# Altium schematic sheet Main Schematic.SchDoc("Main Schematic")
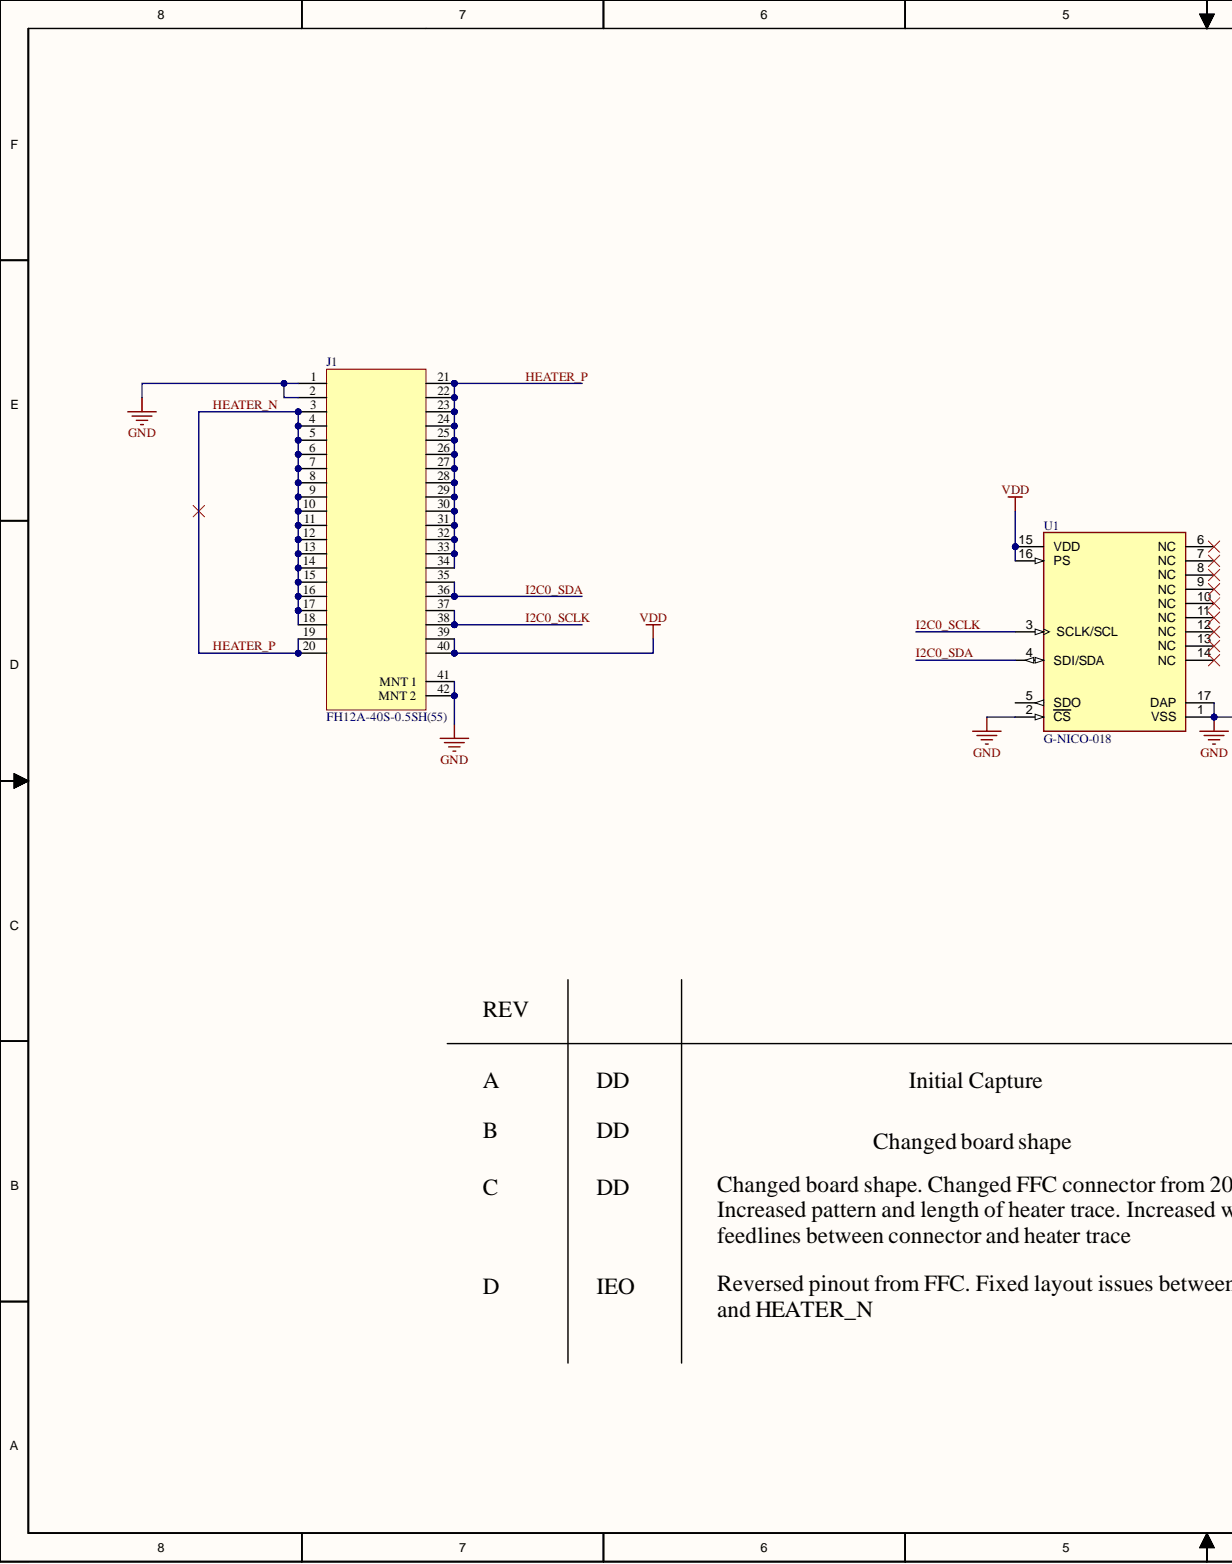
[diagram p0: Main Schematic.SchDoc, left]
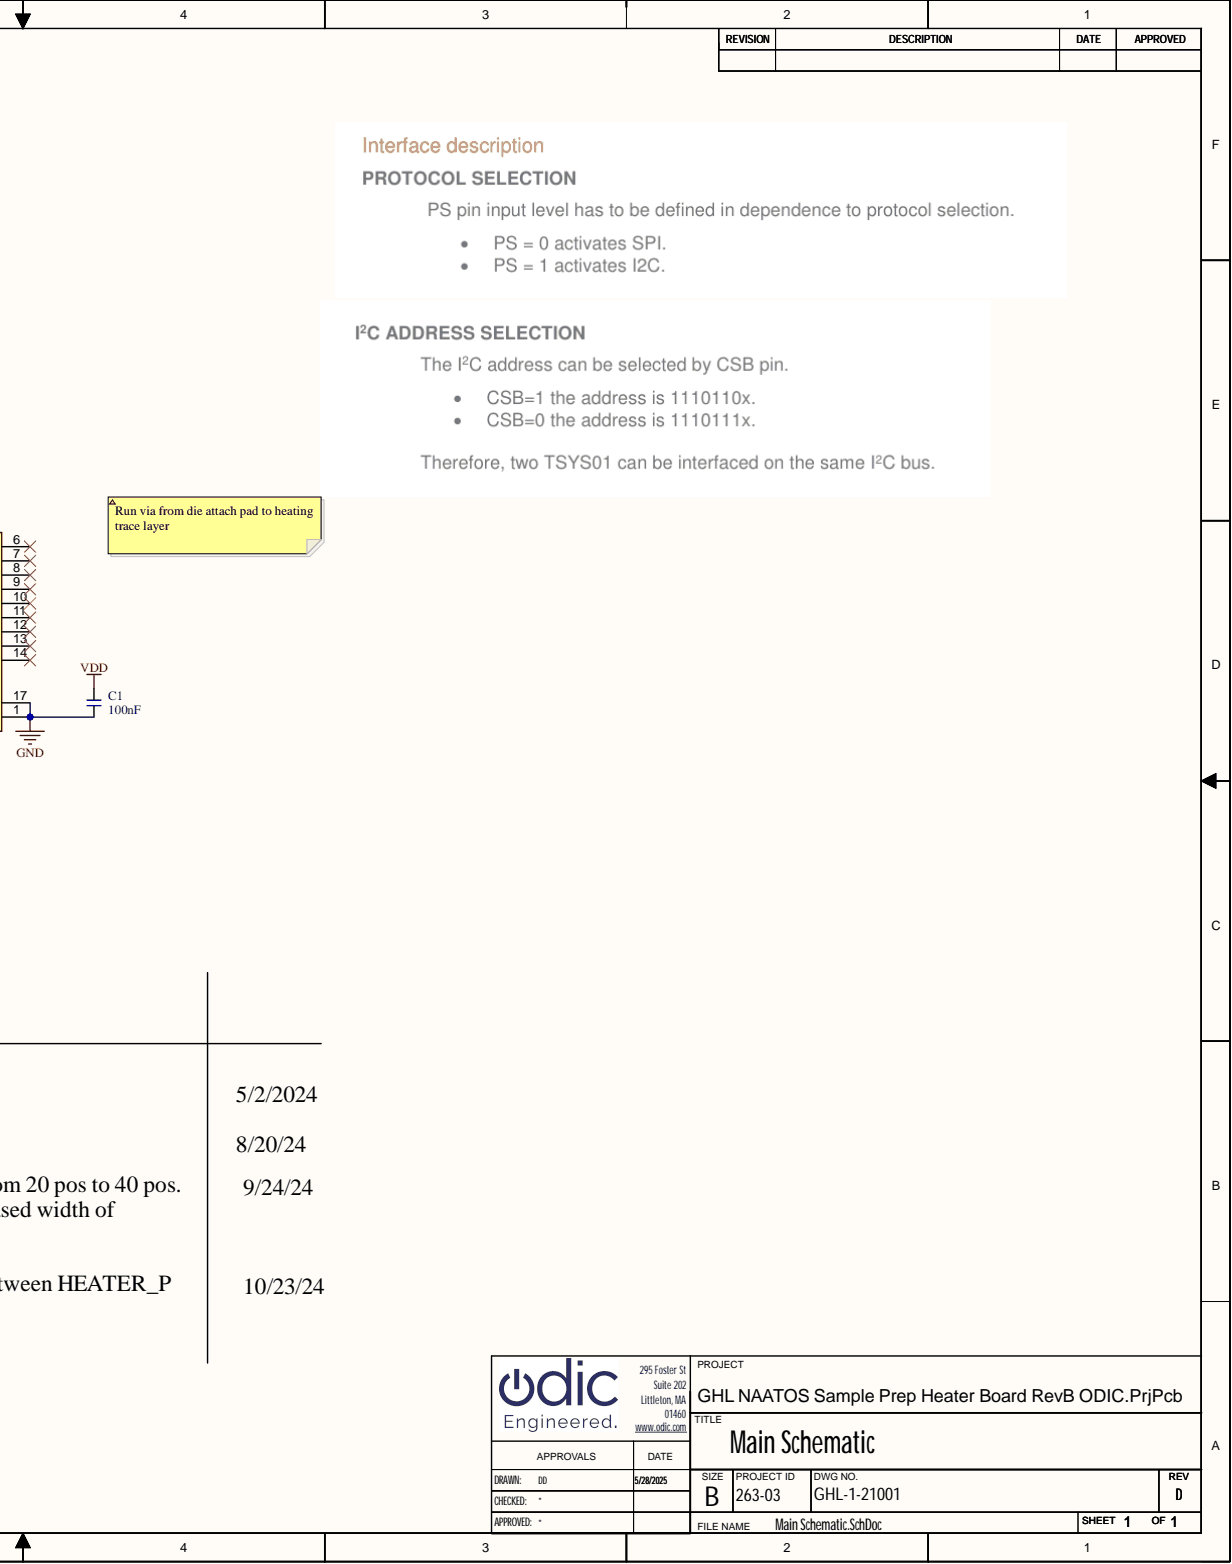
[diagram p1: Main Schematic.SchDoc, right]

## Parts
C1  100nF  [C0402]
J1  FH12A-40S-0.5SH(55)  [hirose_fh12a-40s-0p5sh55]
U1  G-NICO-018  [QFN16_4X4_P65mm]

## Nets
GND: C1.1 J1.1 J1.2 J1.41(MNT_1) J1.42(MNT_2) U1.1(VSS) U1.2(CS) U1.17(DAP)
VDD: C1.2 J1.39 J1.40 U1.15(VDD) U1.16(PS)
HEATER_N: J1.3 J1.4 J1.5 J1.6 J1.7 J1.8 J1.9 J1.10 J1.11 J1.12 J1.13 J1.14 J1.15 J1.16 J1.17 J1.18 J1.19 J1.20 J1.21 J1.22 J1.23 J1.24 J1.25 J1.26 J1.27 J1.28 J1.29 J1.30 J1.31 J1.32 J1.33 J1.34
I2C0_SCLK: J1.37 J1.38 U1.3(SCLK/SCL)
I2C0_SDA: J1.35 J1.36 U1.4(SDI/SDA)

Not connected: U1 5(SDO) 6(NC) 7(NC) 8(NC) 9(NC) 10(NC) 11(NC) 12(NC) 13(NC) 14(NC)

## Library data of the parts
C1 = CMP-00446-1: Ceramic capacitor SMD 0402 100nF 16V X7R 10%; Install: POP; Case Code (Imperial): 0402; Case Code (Metric): 1005; Case/Package: 0402; Component Kind: Standard; Construction: Flat; Contact Plating: Tin; Depth: 0.5mm; Dielectric: X7R; Height: 0.5mm; Lead Free: Lead Free; Length: 1mm; Material: Ceramic; Max Operating Temperature: 125°C; Min Operating Temperature: -55°C; Mounting Technology: Surface Mount; Package Quantity: 1; Packaging: Tape and Reel; Radiation Hardening: No; RoHS Compliant: Yes; Series: CL; Thickness: 0.022inch; Tolerance: 10%; Value: 100nF; Voltage: 16V; Voltage Rating: 16V; Voltage Rating (DC): 16V; Width: 0.02inch; Library Name: Odic Inc
J1 = CMP-002-00023-3: Conn Ffc/fpc Connector Skt 40 Pos 0.5MM Solder Ra SMD T/r / Conn Ffc Top 40POS 0.50MM R/a; Actuator Color: Brown; Actuator Material: Polyphenylene; Connector Type: Receptacle; Contact Pitch: 500um; Contact Resistance: 50mR; Contact Voltage Rating (AC): 50V; Current Rating: 500mA; Gender: Female; Insulation Resistance: 500MR; Mating Cycles: 20; Max Current Rating: 400mA; Number of Contacts: 40; Wire/Cable Type: Straight; Color: Beige,Brown; Component Kind: Standard; Contact Location: Top; Contact Material: Bronze; Contact Plating: Gold; Depth: 6.2mm; Flammability Rating: UL94 V-0; Housing Color: Beige; Housing Material: Polyamide; Lead Free: Lead Free; Lead Pitch: 0.5mm; Length: 24.5mm; Material: Polyamide; Max Operating Temperature: 70°C; Max Voltage Rating (AC): 50V; Min Operating Temperature: -40°C; Mounting Technology: Surface Mount; Number of Positions: 40; Number of Rows: 1; Orientation: Right Angle; Package Quantity: 2000; Packaging: Tape and Reel; Pitch: 0.5mm; Radiation Hardening: No; Reach SVHC Compliant: No; RoHS Compliant: Yes; Series: FH12; Termination: Solder; Voltage Rating: 50V; Voltage Rating (AC): 50V; Width: 24.1mm; Library Name: Odic Inc
U1 = CMP-00069-3: TSYS01 Series 3.6 V -40 to +125 SMT Digital Temperature Sensor - QFN-16; Max Supply Current: 12.5uA; Operating Supply Voltage: 3.6V; Case/Package: QFN; Component Kind: Standard; Height: 0.85mm; Lead Free: Lead Free; Length: 4mm; Max Operating Temperature: 125°C; Min Operating Temperature: -40°C; RoHS Compliant: Yes; Sensor Type: Temperature; Width: 0.157inch; Library Name: Odic Inc
